# Altium schematic sheet Stm32L4xx.SchDoc(Stm32L4xx)
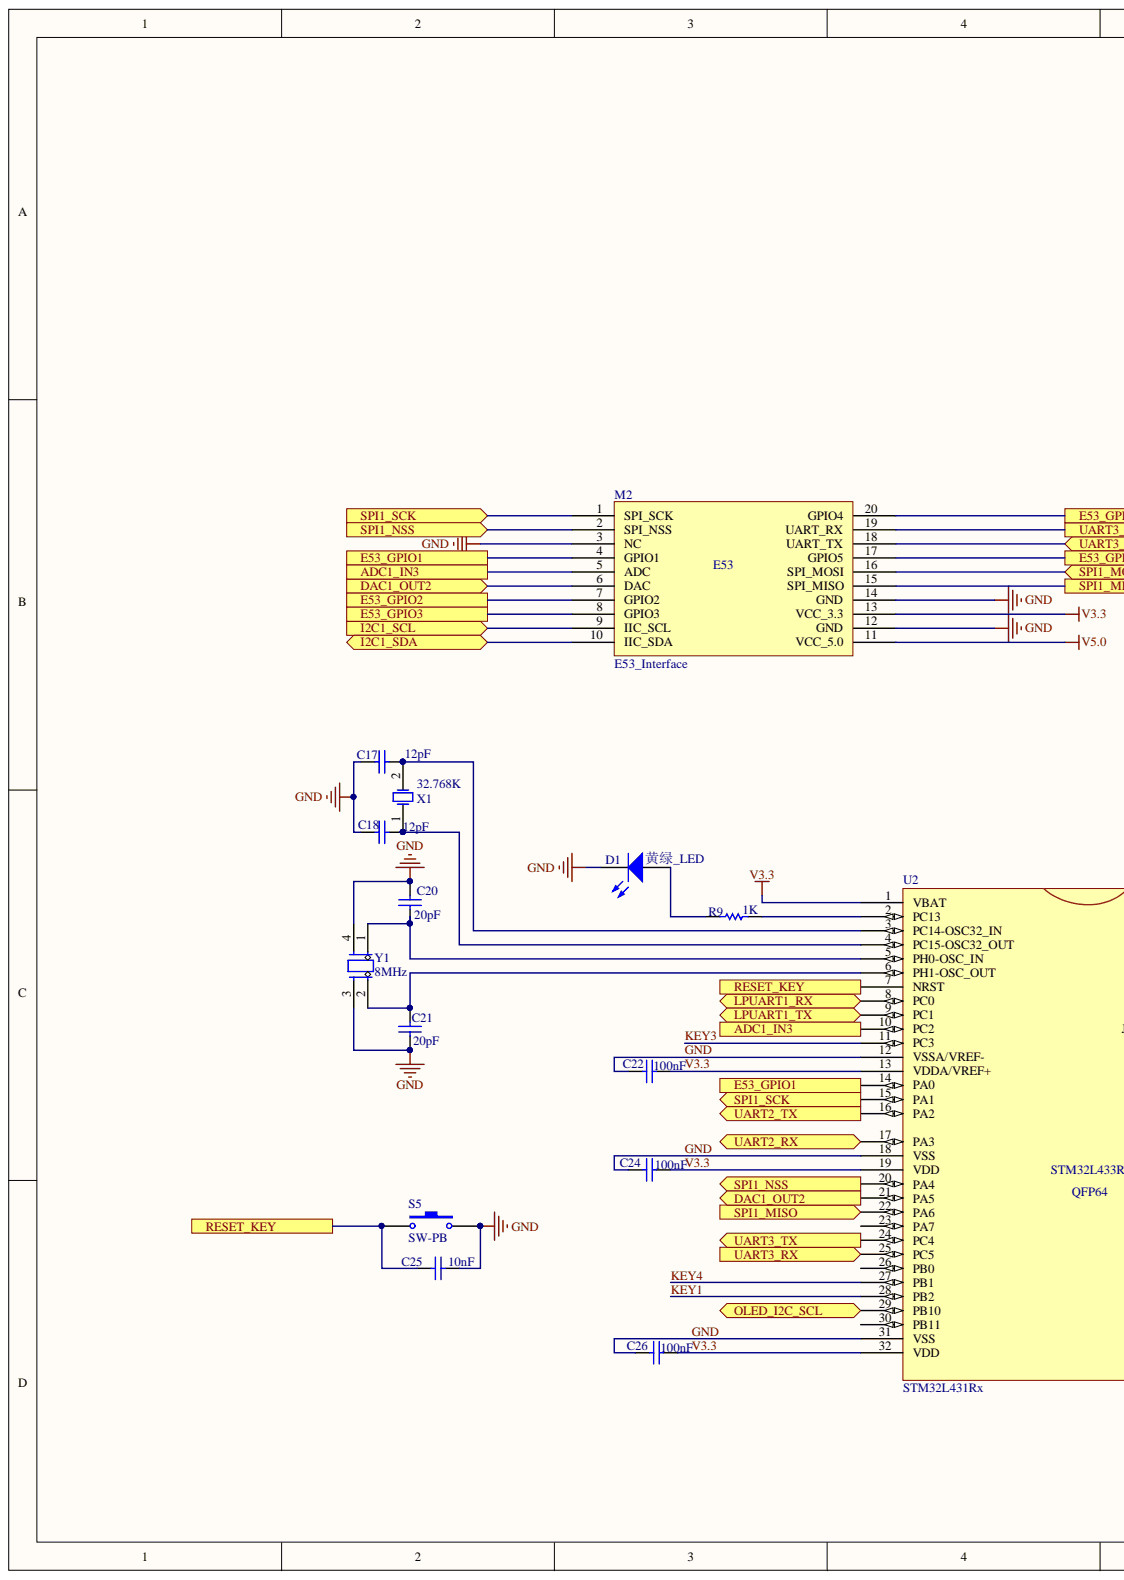
[diagram p0: Stm32L4xx.SchDoc, left]
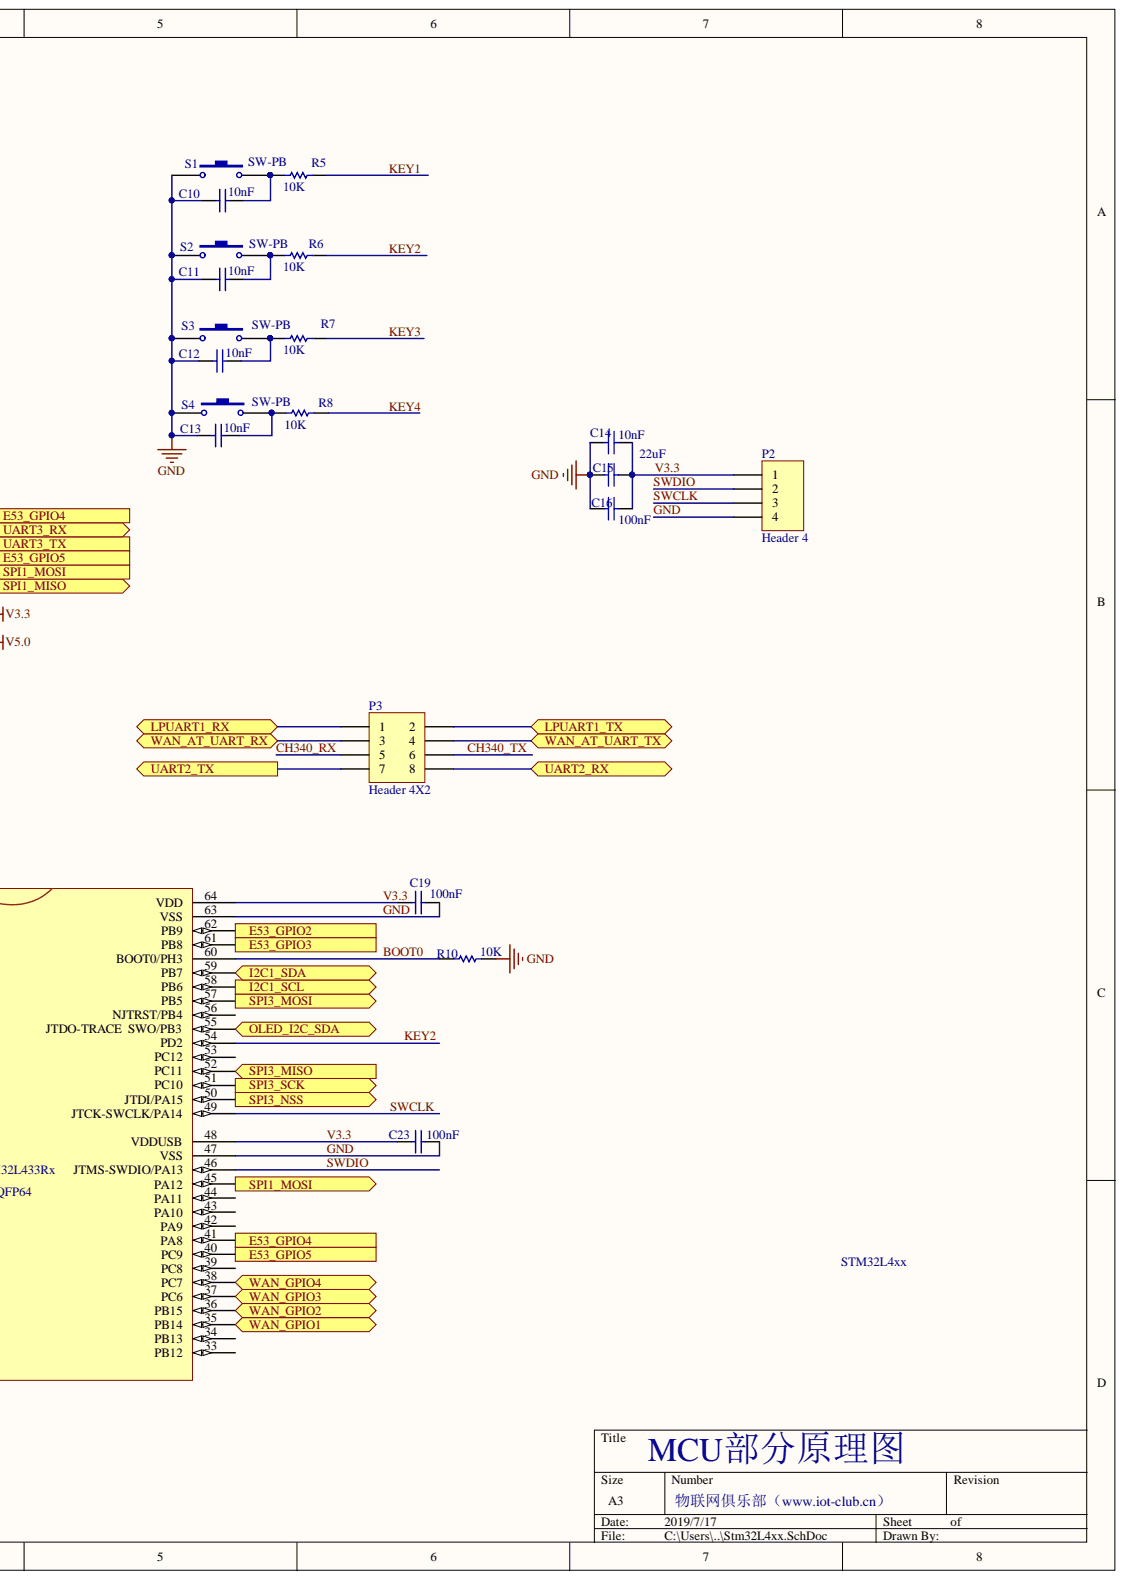
[diagram p1: Stm32L4xx.SchDoc, right]

## Parts
C10  10nF  [C0603]
C11  10nF  [C0603]
C12  10nF  [C0603]
C13  10nF  [C0603]
C14  10nF  [C0603]
C15  22uF  [LC-1206_C]
C16  100nF  [C0603]
C17  12pF  [C0603]
C18  12pF  [C0603]
C19  100nF  [C0603]
C20  20pF  [C0603]
C21  20pF  [C0603]
C22  100nF  [C0603]
C23  100nF  [C0603]
C24  100nF  [C0603]
C25  10nF  [C0603]
C26  100nF  [C0603]
D1  黄绿_LED  [LED_0805]
M2  E53_Interface  [EXT_M_BearPi]
P2  Header 4  [HDR1X4]  (no BOM)
P3  Header 4X2  [HDR2X4_SMT]
R5  10K  [R0603]
R6  10K  [R0603]
R7  10K  [R0603]
R8  10K  [R0603]
R9  1K  [R0603]
R10  10K  [R0603]
S1  SW-PB  [SMD3*6*2.5]  (no BOM)
S2  SW-PB  [SMD3*6*2.5]  (no BOM)
S3  SW-PB  [SMD3*6*2.5]  (no BOM)
S4  SW-PB  [SMD3*6*2.5]  (no BOM)
S5  SW-PB  [SMD3*6*2.5]  (no BOM)
U2  STM32L431Rx  [LQFP64]  (no BOM)
X1  32.768K  [3215]
Y1  8MHz  [XTAL_1]

## Ports
ADC1_IN3, DAC1_OUT2, E53_GPIO1, E53_GPIO2, E53_GPIO3, E53_GPIO4, E53_GPIO5, I2C1_SCL, I2C1_SDA, LPUART1_RX, LPUART1_TX, OLED_I2C_SCL, OLED_I2C_SDA, RESET_KEY, SPI1_MISO, SPI1_MOSI, SPI1_NSS, SPI1_SCK, SPI3_MISO, SPI3_MOSI, SPI3_NSS, SPI3_SCK, UART2_RX, UART2_TX, UART3_RX, UART3_TX, WAN_AT_UART_RX, WAN_AT_UART_TX, WAN_GPIO1, WAN_GPIO2, WAN_GPIO3, WAN_GPIO4

## Nets
V5.0: M2.11(VCC_5.0)
ADC1_IN3: M2.5(ADC) U2.10(PC2) ; port ADC1_IN3
BOOT0: R10.1 U2.60(BOOT0/PH3)
CH340_RX: P3.5
CH340_TX: P3.6
DAC1_OUT2: M2.6(DAC) U2.21(PA5) ; port DAC1_OUT2
E53_GPIO1: M2.4(GPIO1) U2.14(PA0) ; port E53_GPIO1
E53_GPIO2: M2.7(GPIO2) U2.62(PB9) ; port E53_GPIO2
E53_GPIO3: M2.8(GPIO3) U2.61(PB8) ; port E53_GPIO3
E53_GPIO4: M2.20(GPIO4) U2.41(PA8) ; port E53_GPIO4
E53_GPIO5: M2.17(GPIO5) U2.40(PC9) ; port E53_GPIO5
GND: C10.1 C11.1 C12.1 C13.1 C14.1 C15.1 C16.1 C17.1 C18.1 C19.1 C20.2 C21.1 C22.1 C23.1 C24.1 C25.2 C26.1 D1.2 M2.3(NC) M2.12(GND) M2.14(GND) P2.4 R10.2 S1.1 S2.1 S3.1 S4.1 S5.2 U2.12(VSSA/VREF-) U2.18(VSS) U2.31(VSS) U2.47(VSS) U2.63(VSS) Y1.3 Y1.4
I2C1_SCL: M2.9(IIC_SCL) U2.58(PB6) ; port I2C1_SCL
I2C1_SDA: M2.10(IIC_SDA) U2.59(PB7) ; port I2C1_SDA
KEY1: R5.2 U2.28(PB2)
KEY2: R6.2 U2.54(PD2)
KEY3: R7.2 U2.11(PC3)
KEY4: R8.2 U2.27(PB1)
LPUART1_RX: P3.1 U2.8(PC0) ; port LPUART1_RX
LPUART1_TX: P3.2 U2.9(PC1) ; port LPUART1_TX
OLED_I2C_SCL: U2.29(PB10) ; port OLED_I2C_SCL
OLED_I2C_SDA: U2.55(SWO/PB3) ; port OLED_I2C_SDA
RESET_KEY: C25.1 S5.1 U2.7(NRST) ; port RESET_KEY
SPI1_MISO: M2.15(SPI_MISO) U2.22(PA6) ; port SPI1_MISO
SPI1_MOSI: M2.16(SPI_MOSI) U2.45(PA12) ; port SPI1_MOSI
SPI1_NSS: M2.2(SPI_NSS) U2.20(PA4) ; port SPI1_NSS
SPI1_SCK: M2.1(SPI_SCK) U2.15(PA1) ; port SPI1_SCK
SPI3_MISO: U2.52(PC11) ; port SPI3_MISO
SPI3_MOSI: U2.57(PB5) ; port SPI3_MOSI
SPI3_NSS: U2.50(JTDI/PA15) ; port SPI3_NSS
SPI3_SCK: U2.51(PC10) ; port SPI3_SCK
SWCLK: P2.3 U2.49(JTCK-SWCLK/PA14)
SWDIO: P2.2 U2.46(JTMS-SWDIO/PA13)
UART2_RX: P3.8 U2.17(PA3) ; port UART2_RX
UART2_TX: P3.7 U2.16(PA2) ; port UART2_TX
UART3_RX: M2.19(UART_RX) U2.25(PC5) ; port UART3_RX
UART3_TX: M2.18(UART_TX) U2.24(PC4) ; port UART3_TX
V3.3: C14.2 C15.2 C16.2 C19.2 C22.2 C23.2 C24.2 C26.2 M2.13(VCC_3.3) P2.1 U2.1(VBAT) U2.13(VDDA/VREF+) U2.19(VDD) U2.32(VDD) U2.48(VDDUSB) U2.64(VDD)
WAN_AT_UART_RX: P3.3 ; port WAN_AT_UART_RX
WAN_AT_UART_TX: P3.4 ; port WAN_AT_UART_TX
WAN_GPIO1: U2.35(PB14) ; port WAN_GPIO1
WAN_GPIO2: U2.36(PB15) ; port WAN_GPIO2
WAN_GPIO3: U2.37(PC6) ; port WAN_GPIO3
WAN_GPIO4: U2.38(PC7) ; port WAN_GPIO4
NetC10_2: C10.2 R5.1 S1.2
NetC11_2: C11.2 R6.1 S2.2
NetC12_2: C12.2 R7.1 S3.2
NetC13_2: C13.2 R8.1 S4.2
NetC17_2: C17.2 U2.3(PC14-OSC32_IN) X1.2
NetC18_2: C18.2 U2.4(PC15-OSC32_OUT) X1.1
NetC20_1: C20.1 U2.5(PH0-OSC_IN) Y1.1
NetC21_2: C21.2 U2.6(PH1-OSC_OUT) Y1.2
NetD1_1: D1.1 R9.2
NetR9_1: R9.1 U2.2(PC13)

Not connected: U2 23(PA7) 26(PB0) 30(PB11) 33(PB12) 34(PB13) 39(PC8) 42(PA9) 43(PA10) 44(PA11) 53(PC12) 56(NJTRST/PB4)

## Library data of the parts
C10-C26 = CAP: Capacitor; Library Name: Devices.IntLib; Component Kind: Standard
D1 = LED1: Typical RED GaAs LED; Simulation: LED1; Published: 8-Jun-2000; PackageReference: LED-1; PackageDescription: LED; 2 Leads; Library Name: Devices.IntLib; LatestRevisionNote: Mech Layer 1 removed.; LatestRevisionDate: 08-Jul-2005; Component Kind: Standard; Publisher: Altium Limited
M2 = E53: Library Name: Schlib1.SchLib; Component Kind: Standard
P2 = Header 4: Header, 4-Pin; Signal Integrity: Connector; Library Name: Connectors.IntLib; LatestRevisionNote: Re-released for DXP Platform.; LatestRevisionDate: 17-Jul-2002; Publisher: Altium Limited
P3 = Header 4X2: 接插件; Library Name: Connectors.IntLib; LatestRevisionNote: Re-released for DXP Platform.; LatestRevisionDate: 17-Jul-2002; Component Kind: Standard; Publisher: Altium Limited
R5-R8 R10 = Res1: Resistor; Value: 1K; Simulation: RESISTOR; Signal Integrity: Res; Published: 8-Jun-2000; PackageReference: AXIAL-0.3; PackageDescription: Axial Device, Thru-Hole; 2 Leads; 0.3 in Pin Spacing; Library Name: Devices.IntLib; LatestRevisionNote: Re-released for DXP Platform.; LatestRevisionDate: 17-Jul-2002; Component Kind: Standard; Publisher: Altium Limited
R9 = Res1: Resistor; Value: 1K; Simulation: RESISTOR; Signal Integrity: Res; Published: 8-Jun-2000; PackageReference: AXIAL-0.3; PackageDescription: Axial Device, Thru-Hole; 2 Leads; 0.3 in Pin Spacing; Library Name: Devices.IntLib; LatestRevisionNote: Re-released for DXP Platform.; LatestRevisionDate: 17-Jul-2002; Component Kind: Standard; Publisher: Altium Limited
S1-S5 = SW-PB: Switch; Published: 24-Mar-1999; PackageReference: SPST-2; PackageDescription: Switch; 2 Leads; Library Name: Devices.IntLib; LatestRevisionNote: Re-released for DXP Platform.; LatestRevisionDate: 17-Jul-2002; Publisher: Altium Limited
U2 = STM32L433Rx: 超低功耗高性能ARM Cortex-M4 32-bit MCU+FPU 80MHz 100DMIPS; Library Name: IC.SchLib
X1 = XTAL_FC135: XTAL; Library Name: Devices.IntLib; Component Kind: Standard
Y1 = XTAL_4: XTAL; Library Name: Devices.IntLib; Component Kind: Standard
